# Altium schematic sheet USB_UART.SchDoc
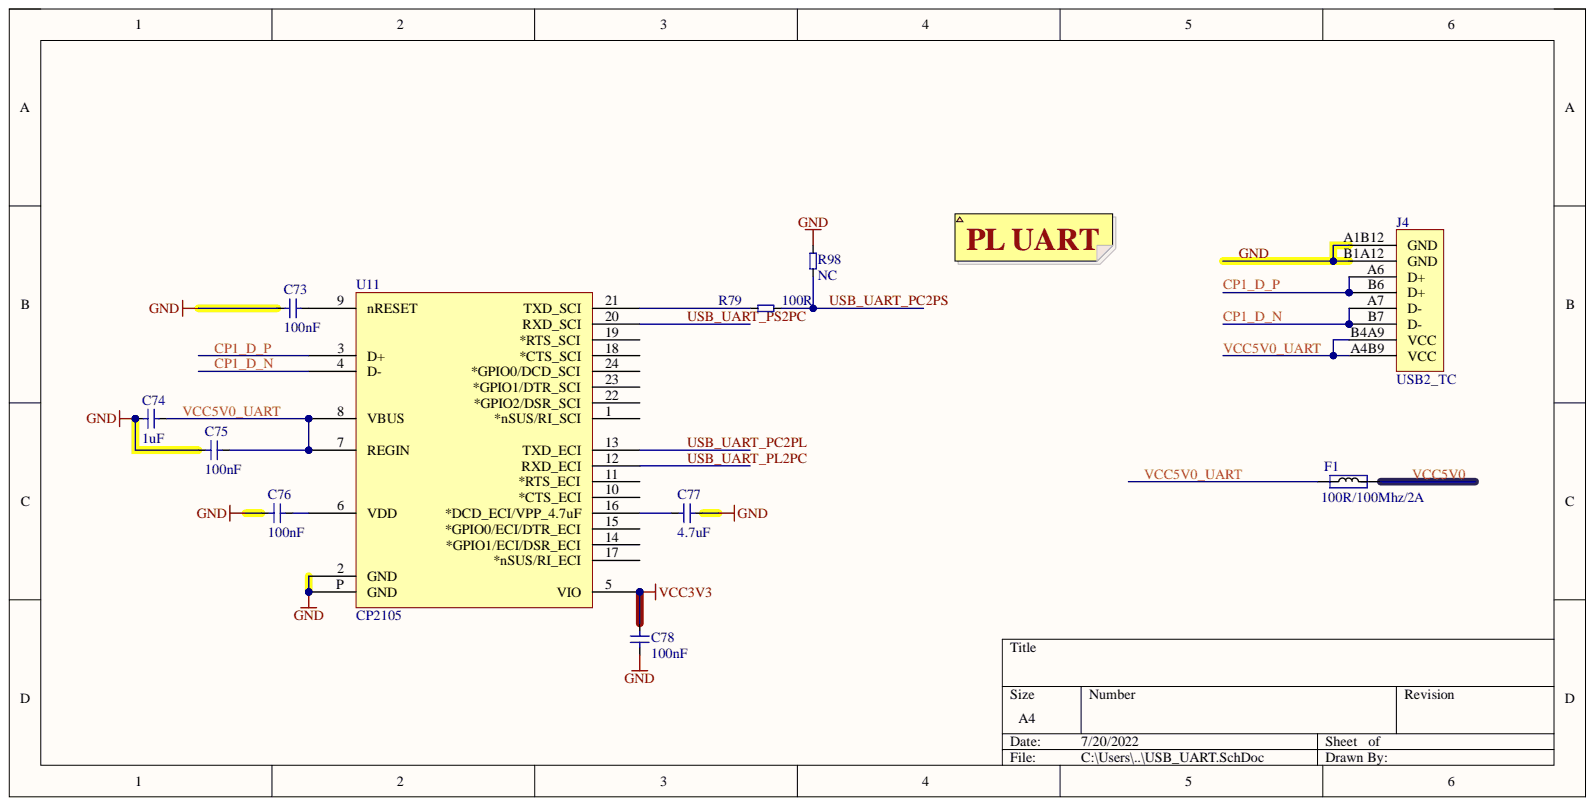
[diagram p0: USB_UART.SchDoc]

## Parts
C73  100nF  [C0201]
C74  1uF  [C0402]
C75  100nF  [C0201]
C76  100nF  [C0201]
C77  4.7uF  [C0603]
C78  100nF  [C0201]
F1  100R/100Mhz/2A  [L0402]
J4  USB2_TC  [TYPE-C_12P_SMT]
R79  100R  [R0201]
R98  NC  [R0201]
U11  CP2105  [QFN24]

## Nets
VCC3V3: C78.2 U11.5(VIO)
CP1_D_N: J4.A7(D-) J4.B7(D-) U11.4(D-)
CP1_D_P: J4.A6(D+) J4.B6(D+) U11.3(D+)
GND: C73.2 C74.1 C75.1 C76.1 C77.2 C78.1 J4.A1B12(GND) J4.B1A12(GND) R98.2 U11.2(GND) U11.P(GND)
USB_UART_PC2PL: U11.13(TXD_ECI)
USB_UART_PC2PS: R79.2 R98.1
USB_UART_PL2PC: U11.12(RXD_ECI)
USB_UART_PS2PC: U11.20(RXD_SCI)
VCC5V0: F1.2
VCC5V0_UART: C74.2 C75.2 F1.1 J4.A4B9(VCC) J4.B4A9(VCC) U11.7(REGIN) U11.8(VBUS)
NetC73_1: C73.1 U11.9(nRESET)
NetC76_2: C76.2 U11.6(VDD)
NetC77_1: C77.1 U11.16(*DCD_ECI/VPP_4.7uF)
NetR79_1: R79.1 U11.21(TXD_SCI)

Not connected: U11 1(*nSUS/RI_SCI) 10(*CTS_ECI) 11(*RTS_ECI) 14(*GPIO1/ECI/DSR_ECI) 15(*GPIO0/ECI/DTR_ECI) 17(*nSUS/RI_ECI) 18(*CTS_SCI) 19(*RTS_SCI) 22(*GPIO2/DSR_SCI) 23(*GPIO1/DTR_SCI) 24(*GPIO0/DCD_SCI)

## Library data of the parts
C73-C78 = C: Capacitor; Component Kind: Standard; Library Name: 电容-SCH.LIB
F1 = Ferrite: Manufacturer: TDK Corporation; Manufacturer Part Number 1: MPZ2012S601AT000; Part Description: FERRITE BEAD 600 OHM 0805 1LN; Current Rating: 2A; DC Resistance (DCR): 100 mOhm Max; Filter Type: Differential Mode - Single; Impedance @ Frequency: 600 Ohm @ 100MHz; Value: 600R, 2A; Category: Filters; Component Kind: Standard; Height (Max): 0.041" (1.05mm); Mounting Type: Surface Mount; Package / Case: 0805 (2012 Metric); Packaging: Tape & Reel (TR); RoHS: RoHS Compliant; Size / Dimension: 0.079" L x 0.049" W (2.00mm x 1.25mm); Supplier 1: Digi-Key; Supplier Part Number 1: 445-2206-2-ND; Library Name: LimeMicroAltiumLib_schLib.SchLib
R79 R98 = RES2: Component Kind: Standard; Library Name: 电阻-SCH.LIB
J4 = USB2_TC: Component Kind: Standard; Library Name: PCB_Project1.SCHLIB
U11 = CP2105: Component Kind: Standard; Library Name: Schlib1.SchLib
